# Altium schematic sheet top.SchDoc
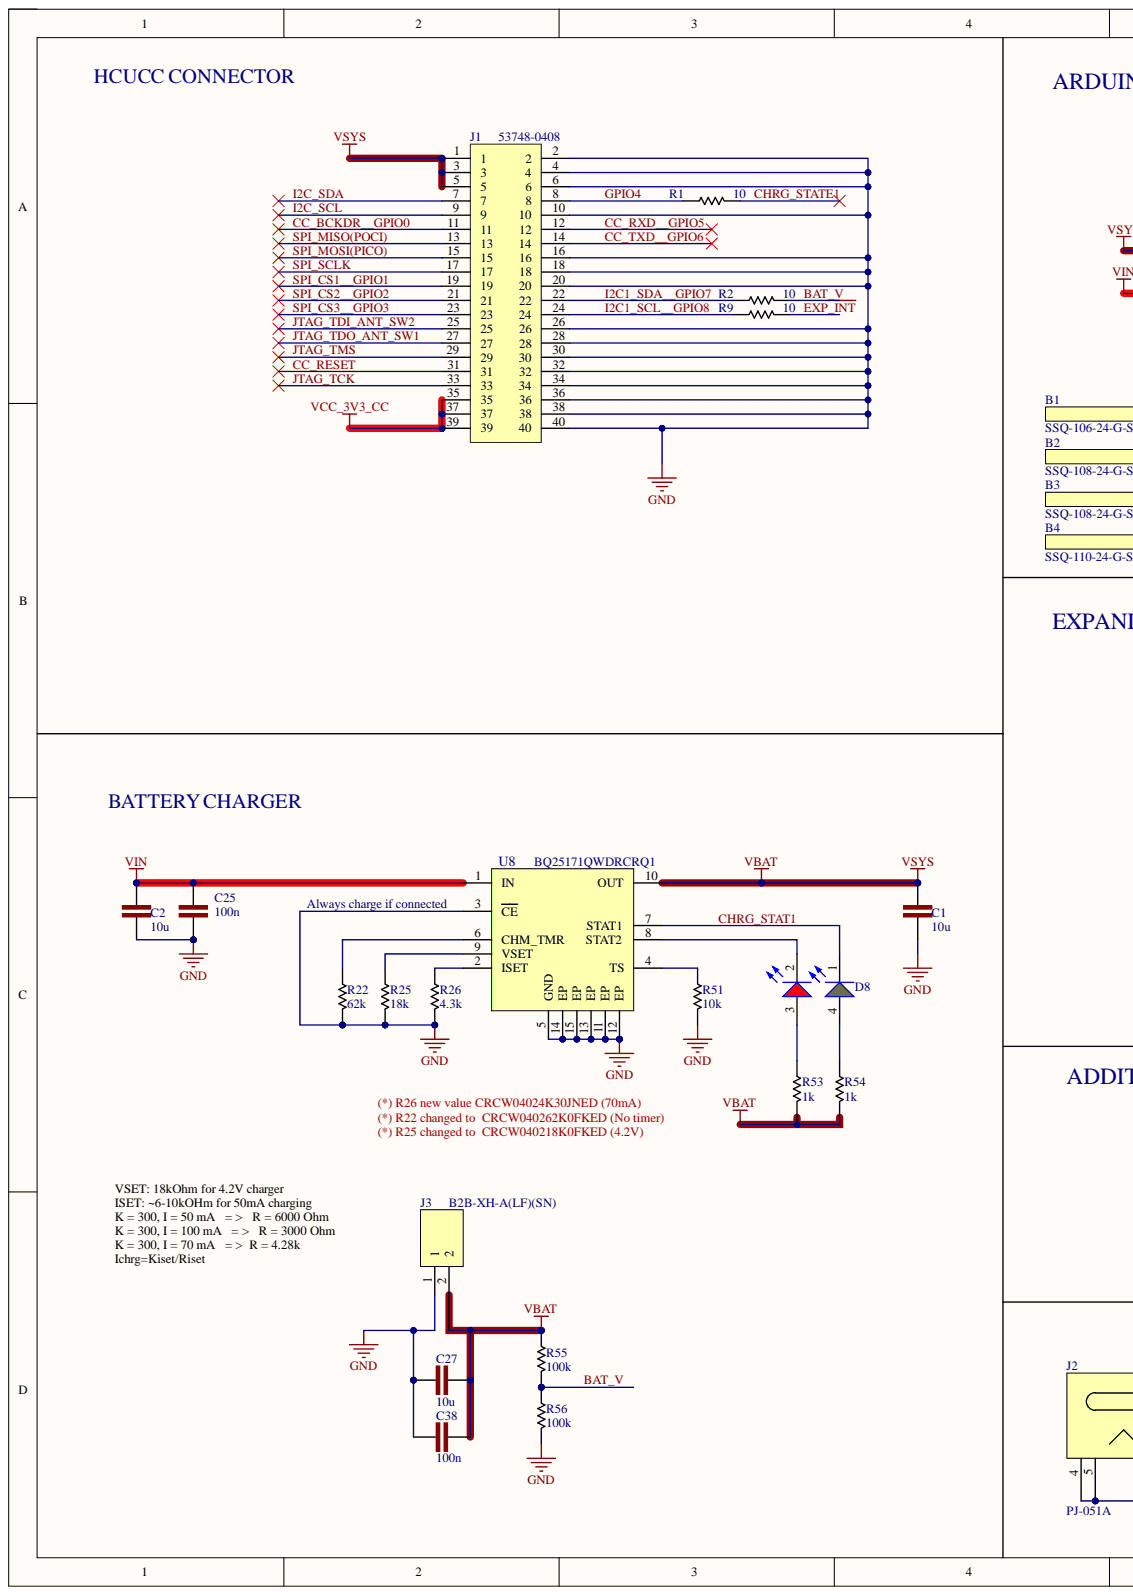
[diagram p0: top.SchDoc, left]
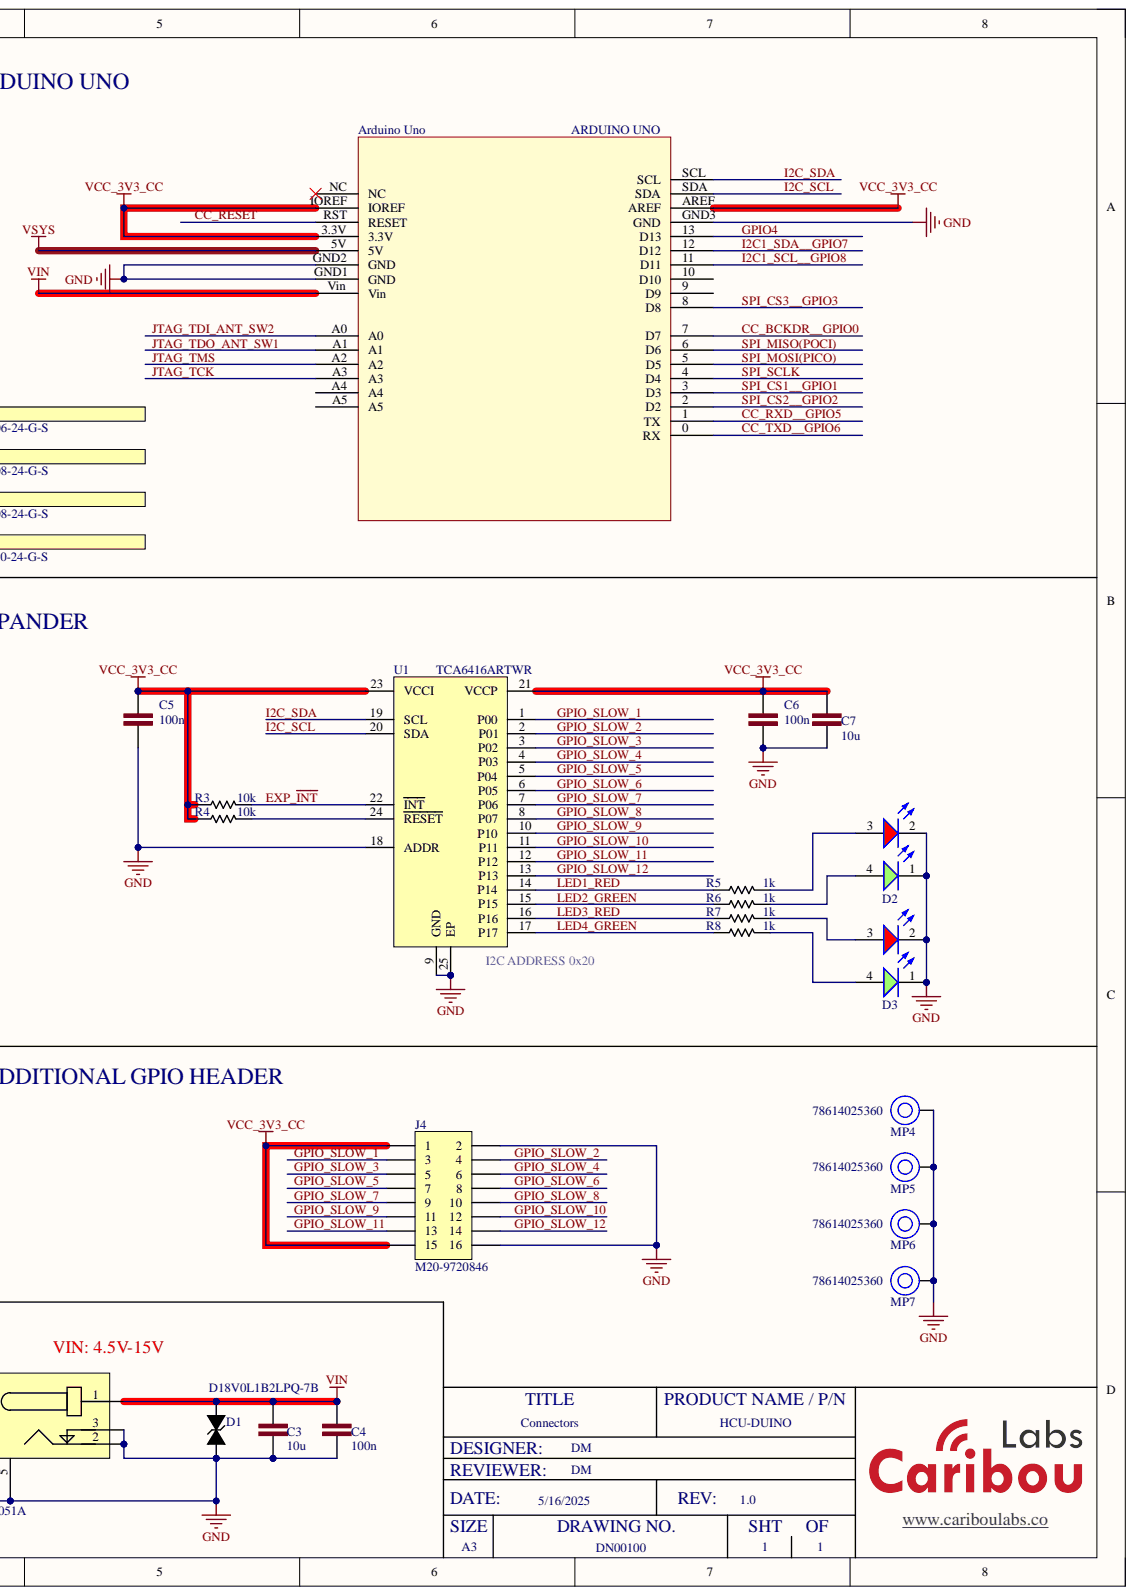
[diagram p1: top.SchDoc, right]

## Parts
Arduino_Uno  ARDUINO UNO  [ARDUINO UNO]
B1  Manu. P/N: SSQ-106-24-G-S  (hidden comment: BOM_ITEM)
B2  Manu. P/N: SSQ-108-24-G-S  (hidden comment: BOM_ITEM)
B3  Manu. P/N: SSQ-108-24-G-S  (hidden comment: BOM_ITEM)
B4  Manu. P/N: SSQ-110-24-G-S  (hidden comment: BOM_ITEM)
C1  10u  [MURATA 0603]
C2  10u  [MURATA 0603]
C3  10u  [MURATA 0603]
C4  100n  [MURATA 0402]
C5  100n  [MURATA 0402]
C6  100n  [MURATA 0402]
C7  10u  [MURATA 0603]
C25  100n  [MURATA 0402]
C27  10u  [MURATA 0603]
C38  100n  [MURATA 0402]
D1  D18V0L1B2LPQ-7B  [DFN65P101X53-2N]
D2  Red & Bright Green, 2V, 140°  [WL-SBCC_0603]  (value not drawn)
D3  Red & Bright Green, 2V, 140°  [WL-SBCC_0603]  (value not drawn)
D8  Red & Bright Green, 2V, 140°  [WL-SBCC_0603]  (value not drawn)
J1  53748-0408  [537480408]
J2  PJ-051A  [PJ-051A]
J3  B2B-XH-A(LF)(SN)  [JST_B2B-XH-A(LF)(SN)]
J4  M20-9720846  [M20-9720846]
MP4  M3 x 2.5mm  [78614025360]  (value not drawn)
MP5  M3 x 2.5mm  [78614025360]  (value not drawn)
MP6  M3 x 2.5mm  [78614025360]  (value not drawn)
MP7  M3 x 2.5mm  [78614025360]  (value not drawn)
R1  10  [PANASONIC 0402]
R2  10  [PANASONIC 0402]
R3  10k  [PANASONIC 0201]
R4  10k  [PANASONIC 0201]
R5  1k  [PANASONIC 0402]
R6  1k  [PANASONIC 0402]
R7  1k  [PANASONIC 0402]
R8  1k  [PANASONIC 0402]
R9  10  [PANASONIC 0402]
R22  62k  [PANASONIC 0402]
R25  18k  [PANASONIC 0402]
R26  4.3k  [PANASONIC 0402]
R51  10k  [PANASONIC 0402]
R53  1k  [PANASONIC 0402]
R54  1k  [PANASONIC 0402]
R55  100k  [PANASONIC 0402]
R56  100k  [PANASONIC 0402]
U1  TCA6416ARTWR  [QFN50P400X400X80-25N-D]
U8  BQ25171QWDRCRQ1  [BQ25171QWDRCRQ1]

## Nets
GND: Arduino_Uno.GND1(GND) Arduino_Uno.GND2(GND) Arduino_Uno.GND3(GND) C1.1 C2.2 C3.2 C4.1 C5.2 C6.2 C7.2 C25.2 C27.2 C38.1 D1.1 D2.1 D2.2 D3.1 D3.2 J1.2 J1.4 J1.6 J1.10 J1.16 J1.18 J1.20 J1.26 J1.28 J1.30 J1.32 J1.34 J1.36 J1.38 J1.40 J2.2 J2.3 J2.4 J2.5 J3.1 J4.2 J4.16 MP4.1 MP5.1 MP6.1 MP7.1 R22.2 R25.2 R26.2 R51.2 R56.2 U1.9(GND) U1.18(ADDR) U1.25(EP) U8.3(CE) U8.5(GND) U8.11(EP) U8.12(EP) U8.13(EP) U8.14(EP) U8.15(EP)
VBAT: Arduino_Uno.5V C1.2 C27.1 C38.2 J1.1 J1.3 J1.5 J3.2 R53.2 R54.2 R55.1 U8.10(OUT)
VCC_3V3_CC: Arduino_Uno.3.3V Arduino_Uno.AREF Arduino_Uno.IOREF C5.1 C6.1 C7.1 J1.35 J1.37 J1.39 J4.1 J4.15 R3.1 R4.1 U1.21(VCCP) U1.23(VCCI)
VIN: Arduino_Uno.Vin C2.1 C3.1 C4.2 C25.1 D1.2 J2.1 U8.1(IN)
BAT_V: R2.2 R55.2 R56.1
CC_BCKDR__GPIO0: Arduino_Uno.7(D7) J1.11
CC_RESET: Arduino_Uno.RST(RESET) J1.31
CC_RXD__GPIO5: Arduino_Uno.1(TX) J1.12
CC_TXD__GPIO6: Arduino_Uno.0(RX) J1.14
CHRG_STAT1: D8.1 U8.7(STAT1)
CHRG_STATE1: R1.2
EXP_~{INT}: R3.2 R9.2 U1.22(INT)
GPIO4: Arduino_Uno.13(D13) J1.8 R1.1
GPIO_SLOW_1: J4.3 U1.1(P00)
GPIO_SLOW_2: J4.4 U1.2(P01)
GPIO_SLOW_3: J4.5 U1.3(P02)
GPIO_SLOW_4: J4.6 U1.4(P03)
GPIO_SLOW_5: J4.7 U1.5(P04)
GPIO_SLOW_6: J4.8 U1.6(P05)
GPIO_SLOW_7: J4.9 U1.7(P06)
GPIO_SLOW_8: J4.10 U1.8(P07)
GPIO_SLOW_9: J4.11 U1.10(P10)
GPIO_SLOW_10: J4.12 U1.11(P11)
GPIO_SLOW_11: J4.13 U1.12(P12)
GPIO_SLOW_12: J4.14 U1.13(P13)
I2C1_SCL__GPIO8: Arduino_Uno.11(D11) J1.24 R9.1
I2C1_SDA__GPIO7: Arduino_Uno.12(D12) J1.22 R2.1
I2C_SCL: Arduino_Uno.SDA J1.9 U1.20(SDA)
I2C_SDA: Arduino_Uno.SCL J1.7 U1.19(SCL)
JTAG_TCK: Arduino_Uno.A3 J1.33
JTAG_TDI_ANT_SW2: Arduino_Uno.A0 J1.25
JTAG_TDO_ANT_SW1: Arduino_Uno.A1 J1.27
JTAG_TMS: Arduino_Uno.A2 J1.29
LED1_RED: R5.1 U1.14(P14)
LED2_GREEN: R6.1 U1.15(P15)
LED3_RED: R7.1 U1.16(P16)
LED4_GREEN: R8.1 U1.17(P17)
SPI_CS1__GPIO1: Arduino_Uno.3(D3) J1.19
SPI_CS2__GPIO2: Arduino_Uno.2(D2) J1.21
SPI_CS3__GPIO3: Arduino_Uno.8(D8) J1.23
SPI_MISO(POCI): Arduino_Uno.6(D6) J1.13
SPI_MOSI(PICO): Arduino_Uno.5(D5) J1.15
SPI_SCLK: Arduino_Uno.4(D4) J1.17
NetD2_3: D2.3 R5.2
NetD2_4: D2.4 R6.2
NetD3_3: D3.3 R7.2
NetD3_4: D3.4 R8.2
NetD8_2: D8.2 U8.8(STAT2)
NetD8_3: D8.3 R53.1
NetD8_4: D8.4 R54.1
NetR4_2: R4.2 U1.24(RESET)
NetR22_1: R22.1 U8.6(CHM_TMR)
NetR25_1: R25.1 U8.9(VSET)
NetR26_1: R26.1 U8.2(ISET)
NetR51_1: R51.1 U8.4(TS)

Not connected: Arduino_Uno 9(D9) 10(D10) A4 A5 NC

## Library data of the parts
B1-B4 = BOM_ITEM: Generic BOM Item; Component Kind: Standard; Library Name: DM_Lib.IntLib
C1-C3 C7 C27 = Capacitor: Multilayer Ceramic Capacitors MLCC - SMD/SMT 10 uF 35 VDC 20% 0603 X5R; Initial Voltage: 0; Manu. P/N: GRM188R6YA106MA73D; SRF: TBD [GHz]; Temp. Range: [-40, 125]; Vmax: 35V; Component Kind: Standard; Library Name: DM_Lib.IntLib; Simulation: Model Name; Technology: X5R; Tolerance: 20%; Value: 10u
C4-C6 C25 C38 = Capacitor: Multilayer Ceramic Capacitors MLCC - SMD/SMT 0.1 uF 50 VDC 10% 0402 X7R AEC-Q200; Initial Voltage: 0; Manu. P/N: GRT155R71H104KE01D; SRF: TBD [GHz]; Temp. Range: [-40, 125]; Vmax: 50V; Component Kind: Standard; Library Name: DM_Lib.IntLib; Simulation: Model Name; Technology: X5R; Tolerance: 10%; Value: 100n
D1 = D18V0L1B2LPQ-7B: ESD Suppressors / TVS Diodes General 15kV Cont., 20kV Air, 20Vw Protection PP DFN1006-2; Peak Pulse Current (8/20uSec): 2A; Peak Pulse Power (8/20uSec): 68W; Toperation: -55 to 150; Vclamping: 34V; Vworking: 20V; Air Discharge: 20 kV; Component Kind: Standard; Contact Discharge: 15 kV; Library Name: DM_Lib.IntLib; Manu. P/N: D18V0L1B2LPQ-7B
D2 D3 D8 = 150060RV75240: WL-SBCC SMT Bi-color Chip LED Compact, size 0603, Red & Bright Green, 2V, 140°; Manufacturer: Wurth Elektronik; Case/Size Code: 0603; Chip Technology: AlInGaP; Dominant Wavelength (Bright Green): 570nm; Dominant Wavelength (Red): 625nm; Luminous Intensity (Bright Green): 90mcd; Luminous Intensity (Red): 230mcd; Peak Wavelength (Bright Green): 572nm; Peak Wavelength (Red): 630nm; Category: Signal LEDs; Component Kind: Standard; ComponentLink1Description: Website Link; ComponentLink1URL: https://www.we-online.com/redexpert/article/150060RV75240?ad; ComponentLink2Description: Datasheet Link; ComponentLink2URL: https://www.we-online.com/redexpert/spec/150060RV75240?ad; Emitting Color: Red & Bright Green; Forward Voltage (Bright Green): 2V; Forward Voltage (Red): 2V; Height: 0.4mm; Length: 1.6mm; Library Name: DM_Lib.IntLib; Manu. P/N: 150060RV75240; Match Code: WL-SBCC; Mount: Surface Mount; Operating Temperature Max: 85°C; Operating Temperature Min: -40°C; Packaging: Tape and Reel; Simulation: 0603_150060RV75240; Viewing Angle: 140°; Width: 0.8mm
J1 = 53748-0408: Molex B2B 40 Pos, 0.5mm pitch, stack-height 3 mm, mates with 52991-0408; Component Kind: Standard; Library Name: DM_Lib.IntLib; Manu. P/N: 53748-0408
J2 = PJ-051A: DC Power Connectors Power Jack; Component Kind: Standard; Library Name: DM_Lib.IntLib; Manu. P/N: PJ-051A
J3 = B2B-XH-A(LF)(SN): Connector Header Through Hole 2 position 0.098 (2.50mm); Component Kind: Standard; Library Name: DM_Lib.IntLib; Manu. P/N: B2B-XH-A(LF)(SN)
J4 = M20-9720846: Header Male 2X8; Component Kind: Standard; Library Name: DM_Lib.IntLib; Manu. P/N: M20-9720846
MP4-MP7 = 78614025360: WE-SMSA SMT Spacer Studs Automotive, OD 6mm, ID 4.2mm, M3 x 2.5mm; Manufacturer: Wurth Elektronik; Solder Cream Thickness Min: 150µm; Surface: Tin; Tightenning Torque Max: 0.5N*m; Category: Assembly; Component Kind: Standard; ComponentLink1Description: Website Link; ComponentLink1URL: https://www.we-online.com/redexpert/article/78614025360?ad; ComponentLink2Description: Datasheet Link; ComponentLink2URL: https://www.we-online.com/redexpert/spec/78614025360?ad; Height: 2.5mm; Inner Diameter: 4.2mm; Library Name: DM_Lib.IntLib; Manu. P/N: 78614025360; Match Code: WE-SMSA; Material: Steel; Mount: Surface Mount; Operating Temperature Max: 150°C; Operating Temperature Min: -55°C; Outer Diameter: 6mm; Packaging: Tape and Reel; Thread: M3
R1 R2 R9 = Resistor: Dale Thick Film Resistors - SMD 1/16watt 10ohms 1%; Manu. P/N: CRCW040210R0FKED; Power Rating: 62.5 mW (1/16 W); Temp. Ceoff.: 100 PPM / C; Component Kind: Standard; Library Name: DM_Lib.IntLib; Signal Integrity: Guessed Model; Simulation: Model Name; Tolerance: 1%; VALUE: 10
R3 R4 = Resistor: Thick Film Resistors - SMD 1/20watt 10Kohms 1% 100ppm 0201; Manu. P/N: CRCW020110K0FKED; Power Rating: 62.5 mW (1/16 W); Temp. Ceoff.: 100 PPM/C; Component Kind: Standard; Library Name: DM_Lib.IntLib; Signal Integrity: Guessed Model; Simulation: Model Name; Tolerance: 1%; VALUE: 10k
R5-R8 R53 R54 = Resistor: Thick Film Resistors - SMD 1/16watt 1Kohms 1% Commercial Use; Manu. P/N: CRCW04021K00FKEDC; Power Rating: 62.5 mW (1/16 W); Temp. Ceoff.: 100 PPM / C; Component Kind: Standard; Library Name: DM_Lib.IntLib; Signal Integrity: Guessed Model; Simulation: Model Name; Tolerance: 1%; VALUE: 1k
R22 = Resistor: Thick Film Resistors - SMD 1/10watt 62Kohms 1%; Manu. P/N: CRCW040262K0FKED; Power Rating: 62.5 mW (1/16 W); Temp. Ceoff.: 100 PPM / C; Component Kind: Standard; Library Name: DM_Lib.IntLib; Signal Integrity: Guessed Model; Simulation: Model Name; Tolerance: 1%; VALUE: 62k
R25 = Resistor: Thick Film Resistors - SMD 1/16watt 18Kohms 1%; Manu. P/N: CRCW040218K0FKED; Power Rating: 62.5 mW (1/16 W); Temp. Ceoff.: 100 PPM / C; Component Kind: Standard; Library Name: DM_Lib.IntLib; Signal Integrity: Guessed Model; Simulation: Model Name; Tolerance: 1%; VALUE: 18k
R26 = Resistor: Thick Film Resistors - SMD 1/16watt 4.3Kohms 5%; Manu. P/N: CRCW04024K30JNED; Power Rating: 62.5 mW (1/16 W); Temp. Ceoff.: 100 PPM / C; Tolerance: 1%; Component Kind: Standard; Library Name: DM_Lib.IntLib; Signal Integrity: Guessed Model; Simulation: Model Name; VALUE: 4.3k
R51 = Resistor: Thick Film Resistors - SMD 1/16watt 10Kohms 1% Commercial Use; Manu. P/N: CRCW040210K0FKEDC; Power Rating: 62.5 mW (1/16 W); Temp. Ceoff.: 100 PPM/C; Component Kind: Standard; Library Name: DM_Lib.IntLib; Signal Integrity: Guessed Model; Simulation: Model Name; Tolerance: 1%; VALUE: 10k
R55 R56 = Resistor: Thick Film Resistors - SMD 1/20watt 100Kohms 1% 200ppm; Manu. P/N: CRCW0201100KFKED; Power Rating: 50 mW (1/16 W); Temp. Ceoff.: 100 PPM / C; Component Kind: Standard; Library Name: DM_Lib.IntLib; Signal Integrity: Guessed Model; Simulation: Model Name; Tolerance: 1%; VALUE: 100k
U1 = TCA6416ARTWR: Low-Voltage 16-Bit I2C and SMBus I/O Expander, with interrupt; Component Kind: Standard; Library Name: DM_Lib.IntLib; Manu. P/N: TCA6416ARTWR
U8 = BQ25171QWDRCRQ1: AUTOMOTIVE, 800-MA Linear Battery charger IC, 1-2-cell LiIon; Component Kind: Standard; Library Name: DM_Lib.IntLib; Manu. P/N: BQ25171QWDRCRQ1
Arduino_Uno = ARDUINO UNO: Component Kind: Net Tie; Library Name: DM_Lib.IntLib
